# Altium schematic sheet top.SchDoc
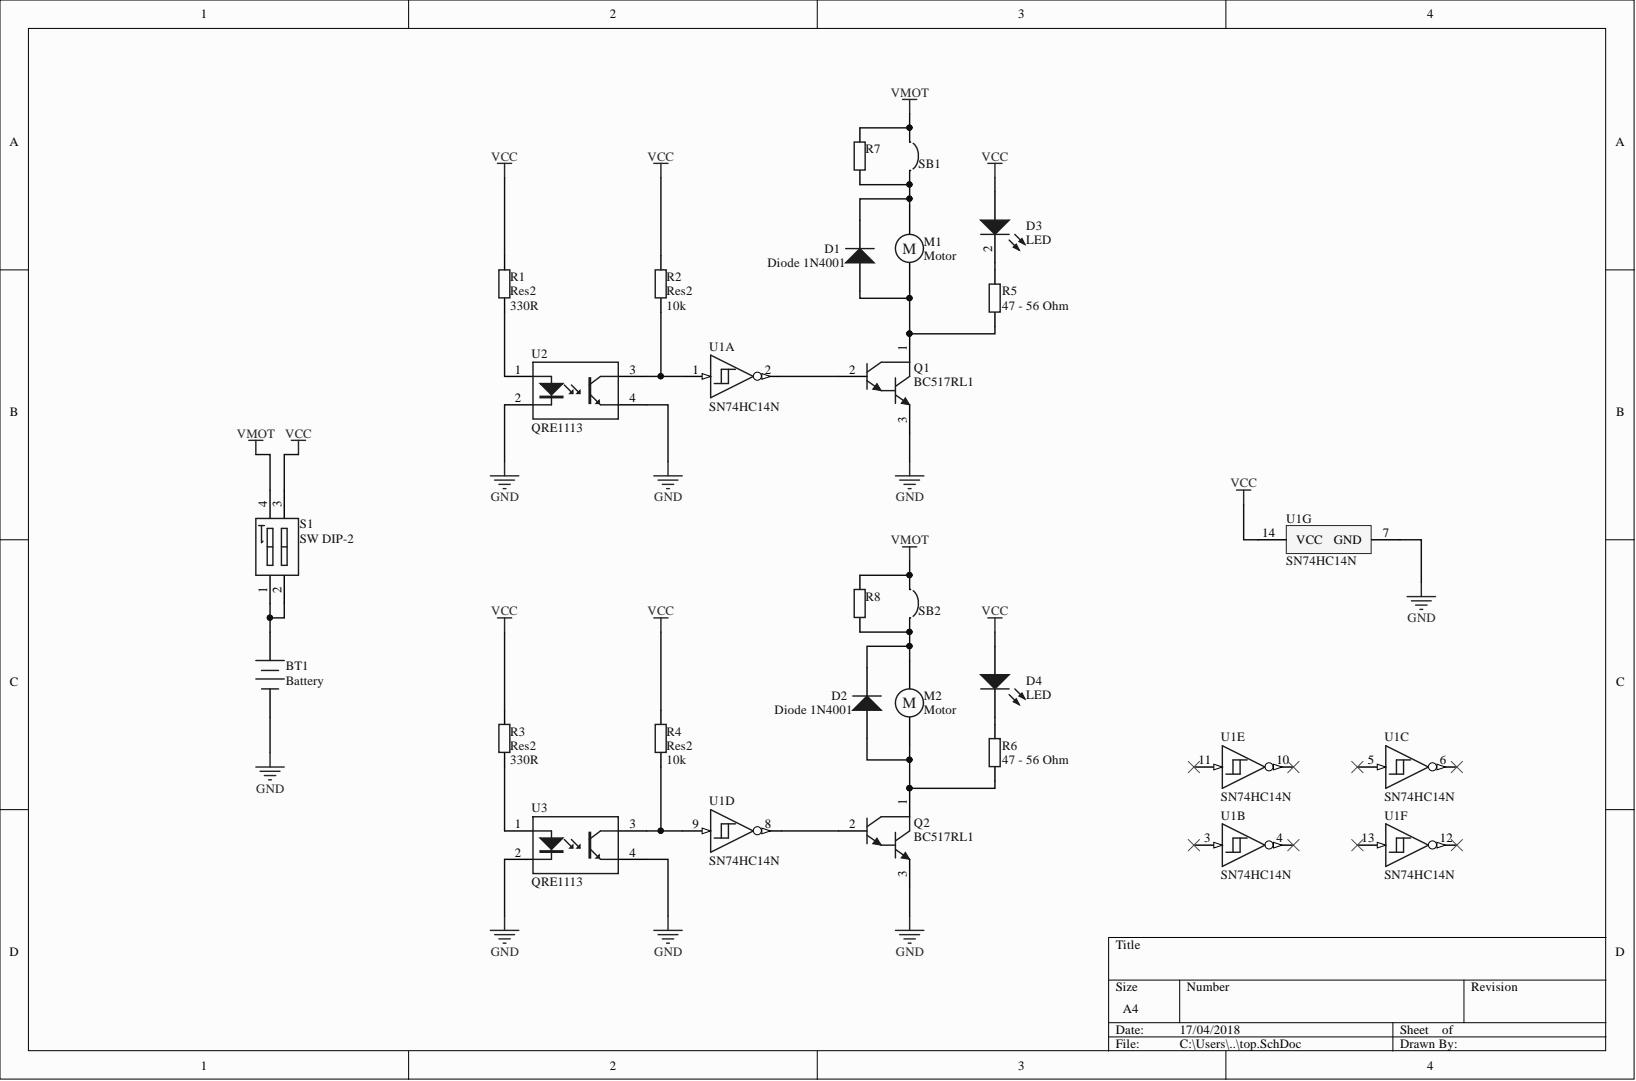
[diagram p0: top.SchDoc]

## Parts
BT1  Battery  [BC2AAPC]
D1  Diode 1N4001  [DO-41]
D2  Diode 1N4001  [DO-41]
D3  LED  [LED]
D4  LED  [LED]
M1  Motor  [Motor Wire Footprint]
M2  Motor  [Motor Wire Footprint]
Q1  BC517RL1  [ONSC-TO-92-3-29-11_AM_BL]
Q2  BC517RL1  [ONSC-TO-92-3-29-11_AM_BL]
R1  Res2  value 330R  [AXIAL-0.4]
R2  Res2  value 10k  [AXIAL-0.4]
R3  Res2  value 330R  [AXIAL-0.4]
R4  Res2  value 10k  [AXIAL-0.4]
R5  value 47 - 56 Ohm  [AXIAL-0.4]  (hidden comment: Res2)
R6  value 47 - 56 Ohm  [AXIAL-0.4]  (hidden comment: Res2)
R7  Res2  [AXIAL-0.4]  (value not drawn)
R8  Res2  [AXIAL-0.4]  (value not drawn)
S1  SW DIP-2  [DIP-4]
SB1  Solder Bridge  [Solder Bridge]  (value not drawn)
SB2  Solder Bridge  [Solder Bridge]  (value not drawn)
U1  SN74HC14N  [N0014A]  (parts A,B,C,D,E,F,G)
U2  QRE1113  [QRE1113_THD]
U3  QRE1113  [QRE1113_THD]

## Nets
GND: BT1.2 Q1.3 Q2.3 U1.7(GND) U2.2 U2.4 U3.2 U3.4
VCC: D3.1 D4.1 R1.2 R2.2 R3.2 R4.2 S1.3 U1.14(VCC)
VMOT: R7.2 R8.2 S1.4 SB1.1 SB2.1
NetBT1_1: BT1.1 S1.1 S1.2
NetD1_1: D1.1 M1.2 Q1.1 R5.1
NetD1_2: D1.2 M1.1 R7.1 SB1.2
NetD2_1: D2.1 M2.2 Q2.1 R6.1
NetD2_2: D2.2 M2.1 R8.1 SB2.2
NetD3_2: D3.2 R5.2
NetD4_2: D4.2 R6.2
NetQ1_2: Q1.2 U1.2
NetQ2_2: Q2.2 U1.8
NetR1_1: R1.1 U2.1
NetR2_1: R2.1 U1.1 U2.3
NetR3_1: R3.1 U3.1
NetR4_1: R4.1 U1.9 U3.3

Not connected: U1 3 4 5 6 10 11 12 13

## Library data of the parts
BT1 = Battery: Multicell Battery; Component Kind: Standard; LatestRevisionDate: 17-Jul-2002; LatestRevisionNote: Re-released for DXP Platform.; Library Name: Miscellaneous Devices.IntLib; PackageDescription: Battery; 2 Leads; PackageReference: BAT-2; Published: 24-Mar-1999; Supplier 1: Digi-Key; Supplier Part Number 1: BC2AAPC-ND; Publisher: Altium Limited
D1 D2 = Diode 1N4001: 1 Amp General Purpose Rectifier; Code_JEDEC: DO-204-AL; Component Kind: Standard; ComponentLink1Description: Manufacturer Link; ComponentLink1URL: http://www.fairchildsemi.com/; ComponentLink2Description: Datasheet; ComponentLink2URL: http://www.fairchildsemi.com/ds/1N%2F1N4001.pdf; DatasheetVersion: 1998; LatestRevisionDate: 17-Jul-2002; LatestRevisionNote: Re-released for DXP Platform.; Library Name: Miscellaneous Devices.IntLib; PackageDescription: Diode, Axial; Body 5.2 x 2.7 mm (LxDia. max), Lead Dia. 0.86 mm (max); PackageReference: DO-41; PackageVersion: Aug-1999; Published: 8-Jun-2000; Signal Integrity: 1N4001; Simulation: 1N4001; Publisher: Altium Limited
D3 D4 = LED0: Typical INFRARED GaAs LED; Component Kind: Standard; LatestRevisionDate: 08-Jul-2005; LatestRevisionNote: Mech Layer 1 removed.; Library Name: Miscellaneous Devices.IntLib; PackageDescription: LED; 2 Leads; PackageReference: LED-0; Published: 8-Jun-2000; Simulation: LED0; Supplier 1: Farnell; Supplier Part Number 1: 1142587; Publisher: Altium Limited
M1 M2 = Motor: Motor, General Kind; Component Kind: Standard; LatestRevisionDate: 17-Jul-2002; LatestRevisionNote: Re-released for DXP Platform.; Library Name: components.SchLib; PackageDescription: Capacitor (Radial); 2 Leads; PackageReference: RB5-10.5; Published: 24-Mar-1999; Supplier 1: Farnell; Supplier Part Number 1: 599104; Publisher: Altium Limited
Q1 Q2 = CMP-1031-00058-1: Darlington Transistor, NPN Silicon, 3-Pin TO-92, Tape and Reel; Manufacturer: On Semiconductor; IC Continuous (A): 1; Component Kind: Standard; ComponentLink1Description: Manufacturer URL; ComponentLink1URL: http://www.onsemi.com/; ComponentLink2Description: Datasheet; ComponentLink2URL: http://www.onsemi.cn/pub_link/Collateral/BC517-D.PDF; ComponentLink3Description: Package Specification; ComponentLink3URL: http://www.onsemi.com/pub_link/Collateral/MAC97-D.PDF; DatasheetVersion: Rev. 5; Library Name: Altium Content Vault; Mounting Technology: Through Hole; PackageDescription: 3-Pin Transistor Outline, Body 4.825 x 3.685 mm, Pitch 1.27 mm; PackageReference: TO-92-3-29-11_AM_BL; PackageVersion: Rev. AM; Packing: Tape and Reel; PartNumber: BC517RL1; Polarity: NPN; RoHS: FALSE
R1-R6 = Res2: Resistor; Component Kind: Standard; LatestRevisionDate: 17-Jul-2002; LatestRevisionNote: Re-released for DXP Platform.; Library Name: Miscellaneous Devices.IntLib; PackageDescription: Axial Device, Thru-Hole; 2 Leads; 0.4 in Pin Spacing; PackageReference: AXIAL-0.4; Published: 8-Jun-2000; Signal Integrity: Res; Simulation: RESISTOR; Publisher: Altium Limited
R7 R8 = Res2: Resistor; Value: 1K; Component Kind: Standard; LatestRevisionDate: 17-Jul-2002; LatestRevisionNote: Re-released for DXP Platform.; Library Name: Miscellaneous Devices.IntLib; PackageDescription: Axial Device, Thru-Hole; 2 Leads; 0.4 in Pin Spacing; PackageReference: AXIAL-0.4; Published: 8-Jun-2000; Signal Integrity: Res; Simulation: RESISTOR; Publisher: Altium Limited
S1 = SW DIP-2: DIP Switch, 2 Position, SPST; Component Kind: Standard; LatestRevisionDate: 17-Jul-2002; LatestRevisionNote: Re-released for DXP Platform.; Library Name: Miscellaneous Devices.IntLib; PackageReference: DIP-4; Published: 24-Mar-1999; Simulation: dpsw2; Publisher: Altium Limited
U1 = CMP-1615-00889-3: Hex Schmitt-Trigger Inverters, N0014A, TUBE; Manufacturer: Texas Instruments; Bits(#): 6; F @ Nom Voltage(Max)(Mhz): 20; ICC @ Nom Voltage(Max)(mA): 0.02; Input Type: CMOS; Output Drive (IOL/IOH)(Max)(mA): -4/4; Rating: Catalog; Schmitt Trigger: Yes; Technology Family: HC; tpd @ Nom Voltage(Max)(ns): 31; VCC(Min)(V): 2; Voltage(Nom)(V): 5; Code_JEDEC: MS-001; Component Kind: Standard; DatasheetVersion: SCLS085G; Generic Part Number: SN74HC14; Library Name: Altium Content Vault; Operating Temperature Range(C): -40 to 85; Output Type: CMOS; PackageReference: N0014A; VCC(Max)(V): 6
U2 U3 = CMP-2000-06919-1: Miniature Reflective Object Sensor, 30 V, -40 to 85 degC, 4-Pin THD, RoHS, Tape and Reel; Manufacturer: FairchildSemiconductor; Lead Finish: MatteTin; Maximum Collector Current: 20mA; Maximum Collector Emitter Voltage: 30V; Maximum Fall Time: 20000(Typ)ns; Maximum Forward Current: 50mA; Maximum Reverse Voltage: 5V; Number of Channels per Chip: 1; Rad Hard: No; Wavelength: 940nm; Category: Photointerrupter; Component Kind: Standard; Datasheet URL: https://www.fairchildsemi.com/datasheets/QR/QRE1113.pdf; Datasheet Version: Rev. 2.7 , 04/2016; Manufacturer URL: https://www.fairchildsemi.com/; Maximum Operating Temperature: 85°C; Maximum Rise Time: 20000(Typ)ns; Minimum Operating Temperature: -40°C; Mounting Technology: SurfaceMount; Package: 4MiniatureSMT; Package Description: 4-Pin Surface Mount Device, Body 3.4 x 2.7 mm, Pitch 1.8 mm; Package Reference: QRE1113GR; Packaging: Tape&Reel; Type: Reflective
SB1 SB2 = Solder Bridge: Component Kind: Standard; Description: Solder Bridge; Library Name: components.SchLib
